# Altium schematic sheet LCD.SchDoc
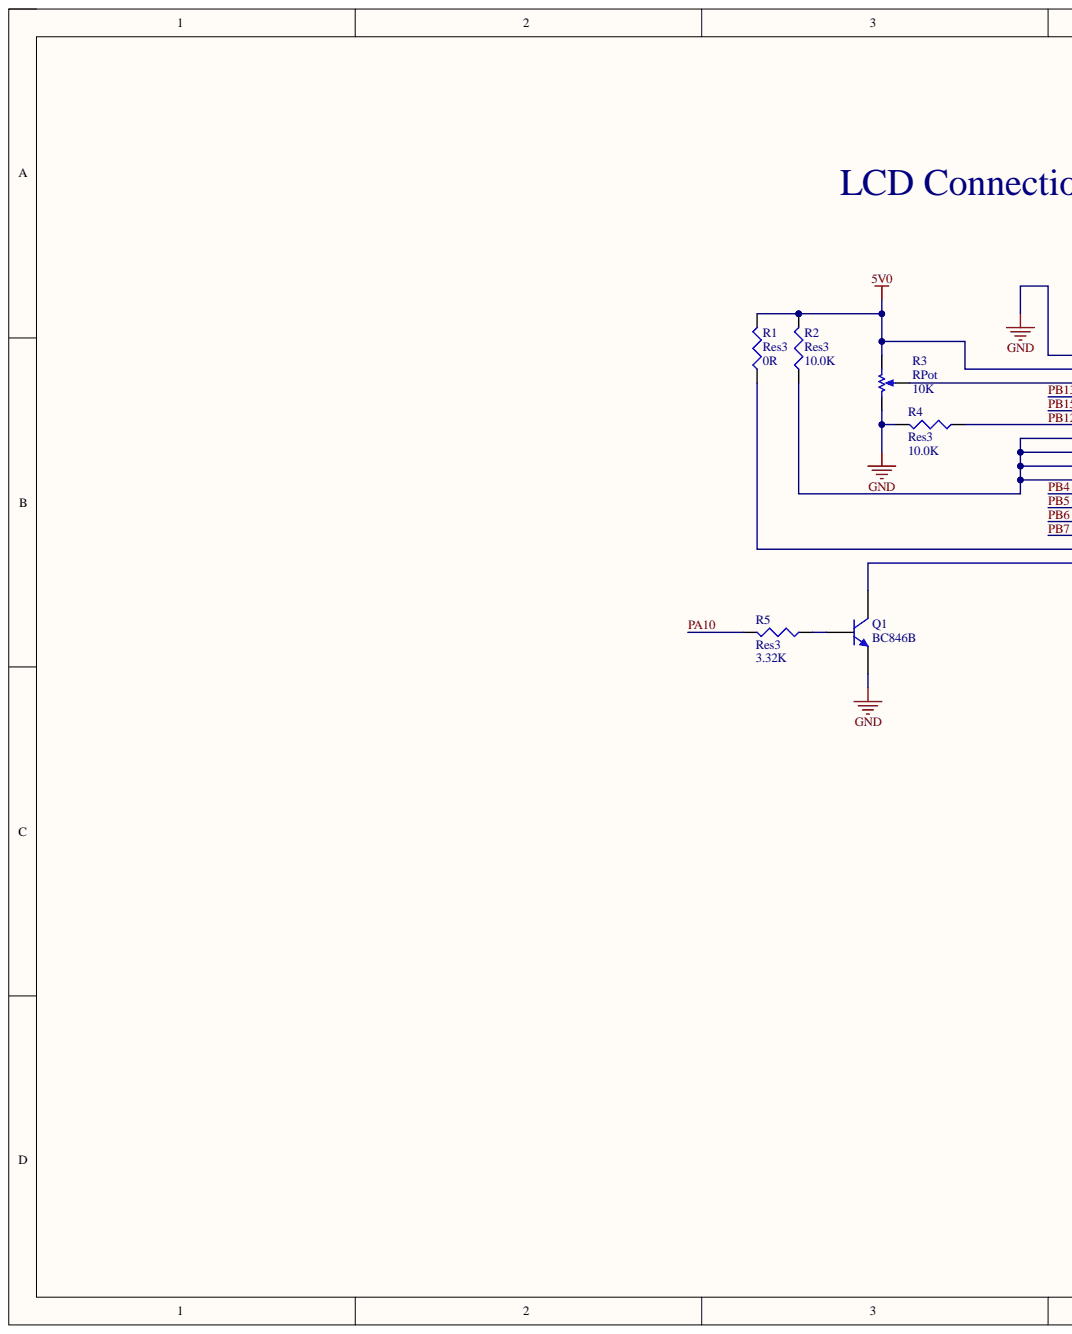
[diagram p0: LCD.SchDoc, left]
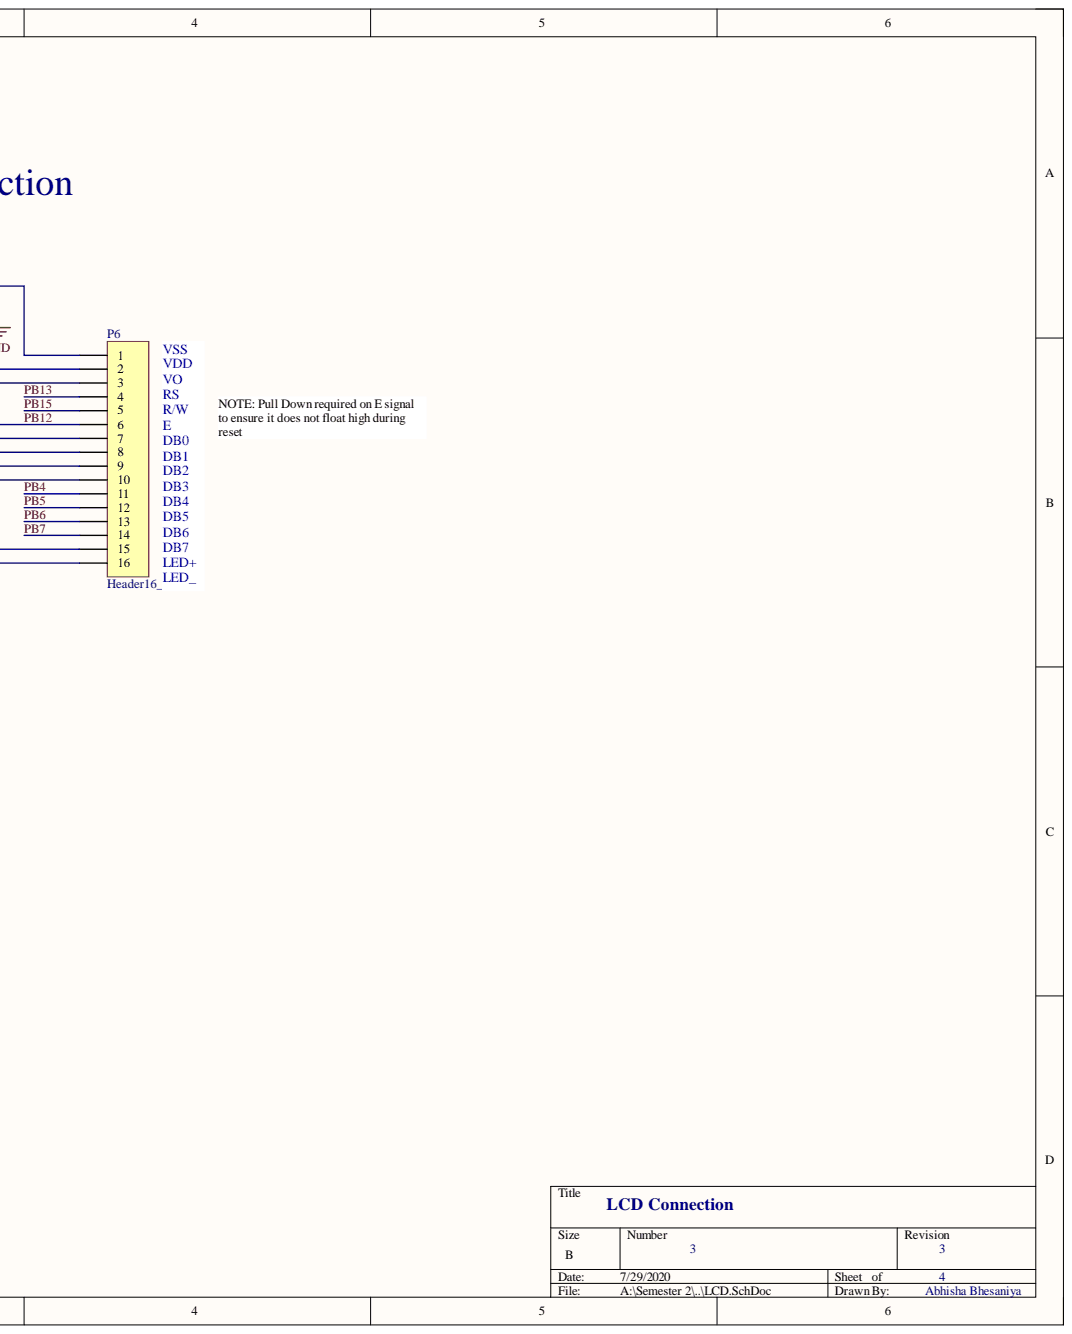
[diagram p1: LCD.SchDoc, right]

## Parts
P6  Header16_LCD  [HDR1X16_LCD]
Q1  BC846B  [SOT95P230X110-3M]
R1  Res3  value 0R  [RESC2112X05M]
R2  Res3  value 10.0K  [RESC2112X05M]
R3  RPot  value 10K  [BOURNS_3386F]
R4  Res3  value 10.0K  [RESC2112X05M]
R5  Res3  value 3.32K  [RESC2112X05M]

## Nets
5V0: P6.2 R1.2 R2.2 R3.1
GND: P6.1 Q1.2 R3.3 R4.2
PA10_3: R5.2  [also labelled PA10]
PB4_3: P6.11  [also labelled PB4]
PB5_3: P6.12  [also labelled PB5]
PB6_3: P6.13  [also labelled PB6]
PB7_3: P6.14  [also labelled PB7]
PB12_3: P6.6 R4.1  [also labelled PB12]
PB13_3: P6.4  [also labelled PB13]
PB15_3: P6.5  [also labelled PB15]
NetP6_3: P6.3 R3.2
NetP6_7: P6.7 P6.8 P6.9 P6.10 R2.1
NetP6_15: P6.15 R1.1
NetP6_16: P6.16 Q1.3
NetQ1_1: Q1.1 R5.1

## Library data of the parts
P6 = Header16_LCD: Header, 16-Pin Female; MPN: PPPC161LFBN-RC; PN: S7049-ND; Component Kind: Standard; Library Name: esd_library.IntLib; Signal Integrity: Connector; URL: http://www.digikey.ca/product-detail/en/PPPC161LFBN-RC/S7049-ND
Q1 = BC846B: TRANS NPN 65V 0.1A SOT23; MPN: BC846BLT3G; PN: BC846BLT3GOSCT-ND; Component Kind: Standard; Library Name: esd_library.IntLib; URL: https://www.digikey.ca/product-detail/en/on-semiconductor/BC846BLT3G/BC846BLT3GOSCT-ND
R1 = Resistor_0R_Jumper: RES SMD 0 OHM 5% 1/8W 0805; MPN: CRCW08050000Z0EA; PN: 541-0.0ACT-ND; Component Kind: Standard; Library Name: esd_library.IntLib; Name: Resistor_0R_Jumper; URL: http://www.digikey.ca/product-detail/en/CRCW08050000Z0EA/541-0.0ACT-ND
R2 R4 = Resistor_10.0K: RES SMD 10.0K OHM 1% 1/8W 0805; MPN: CRCW080510K0FKEA; PN: 541-10.0KCCT-ND; Component Kind: Standard; Library Name: esd_library.IntLib; Name: Resistor_10.0K; URL: http://www.digikey.ca/product-detail/en/CRCW080510K0FKEA/541-10.0KCCT-ND
R3 = RPot: POT 10K OHM THUMBWHEEL CERM ST; MPN: 3352T-1-103LF; PN: 3352T-103LF-ND; Set Position: 0.5; Sim Note: Enter the Resistance value and the set point into parameter fields.; Component Kind: Standard; LatestRevisionDate: 17-Jul-2002; LatestRevisionNote: Re-released for DXP Platform.; Library Name: esd_library.IntLib; PackageDescription: Variable Resistor, Thru-Hole; 3 Leads; PackageReference: VR5; Published: 8-Jun-2000; URL: http://www.digikey.ca/product-detail/en/3352T-1-103LF/3352T-103LF-ND; Publisher: Altium Limited
R5 = Resistor_3.32K: RES SMD 3.32K OHM 1% 1/8W 0805; MPN: CRCW08053K32FKEA; PN: 541-3.32KCCT-ND; Component Kind: Standard; Library Name: esd_library.IntLib; Name: Resistor_3.32K; URL: http://www.digikey.ca/product-detail/en/CRCW08053K32FKEA/541-3.32KCCT-ND
